# Altium schematic sheet Power Regulator Schematic.SchDoc("Power Regulator Schematic")
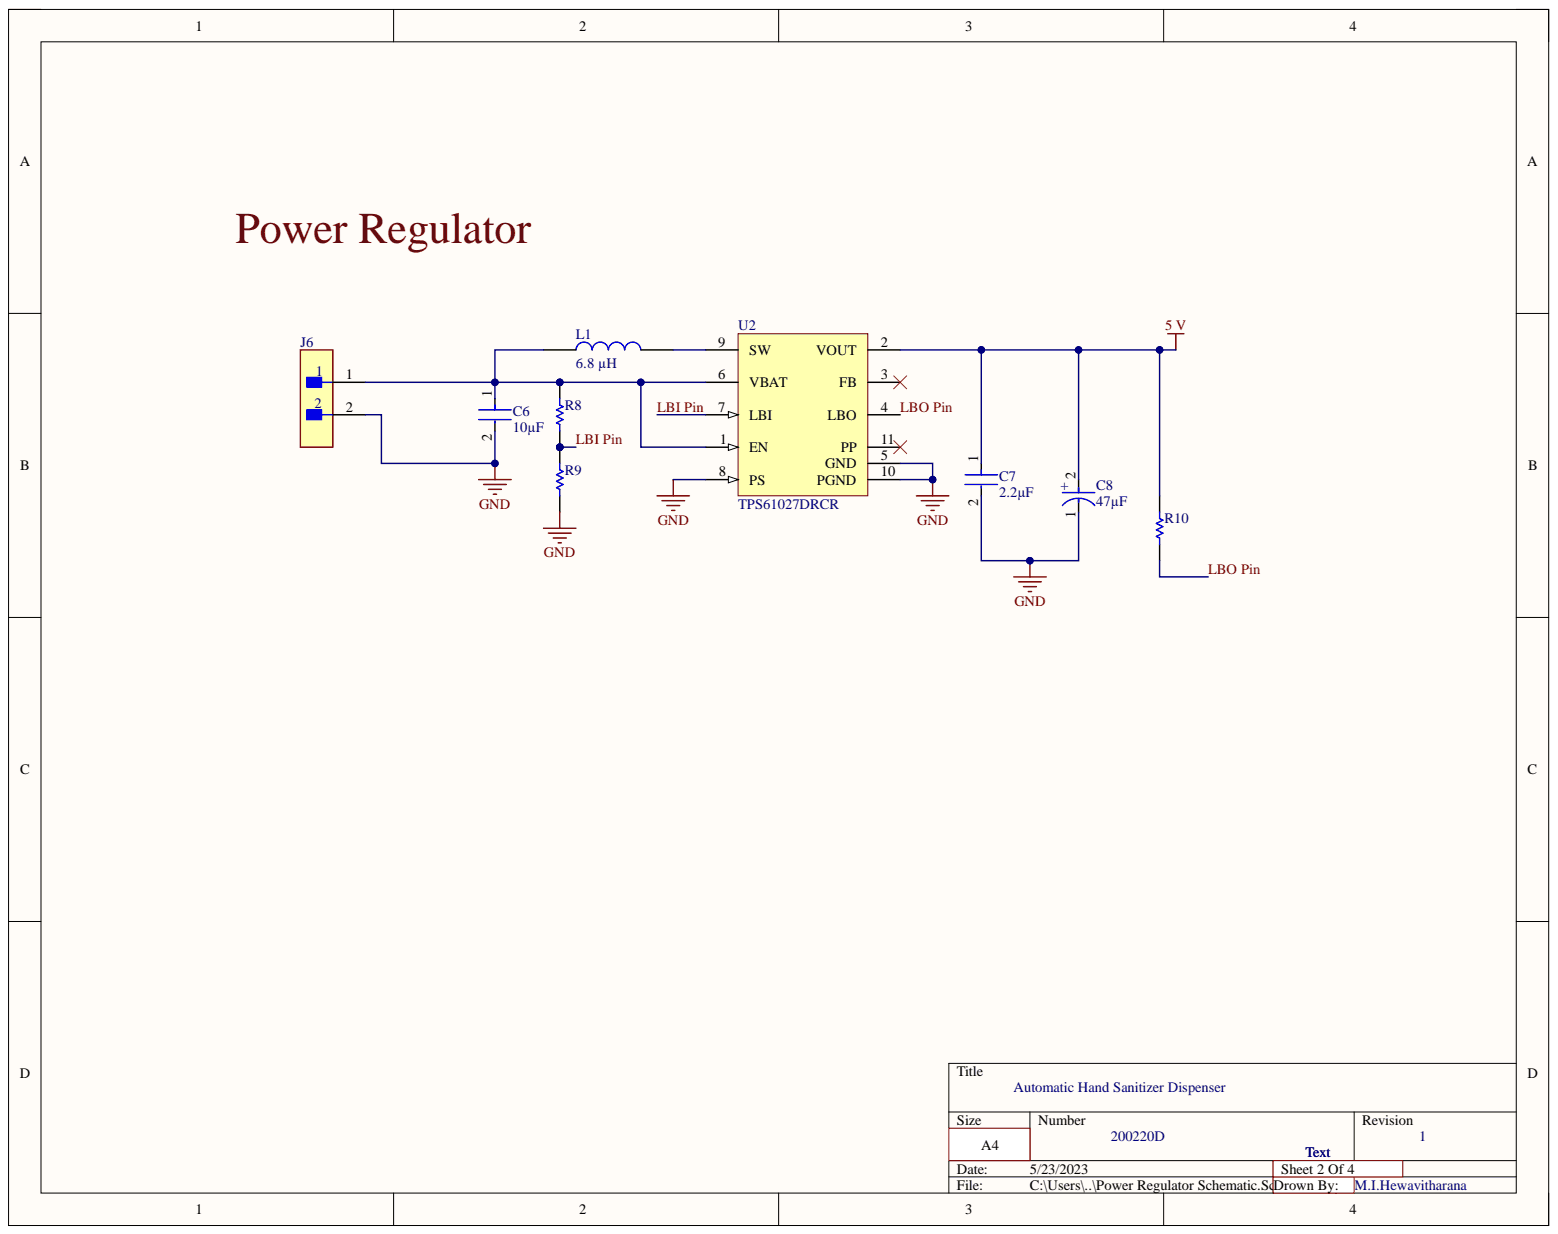
[diagram p0: Power Regulator Schematic.SchDoc]

## Parts
C6  10µF  [FP-VY2472M49Y5UG63V7-MFG]
C7  2.2µF  [FP-VY2472M49Y5UG63V7-MFG]
C8  47µF  [FP-RAD-TH-D_16_0_5-L_25_2-MFG]
J6  TSW-102-07-L-S  [FP-TSW-102-07-L-S-MFG]  (value not drawn)
L1  6.8 µH  [INDPM6363X300N]
R8  MFR-25FBF52-1K  [RESA60-630X240]  (value not drawn)
R9  MFR-25FBF52-1K  [RESA60-630X240]  (value not drawn)
R10  MFR-25FBF52-1K  [RESA60-630X240]  (value not drawn)
U2  TPS61027DRCR  [DRC0010J_V]

## Nets
5 V: C7.1 C8.2 R10.2 U2.2(VOUT)
GND: C6.2 C7.2 C8.1 J6.2 R9.1 U2.5(GND) U2.8(PS) U2.10(PGND)
LBI Pin: R8.1 R9.2 U2.7(LBI)
LBO Pin: R10.1 U2.4(LBO)
NetC6_1: C6.1 J6.1 L1.1 R8.2 U2.1(EN) U2.6(VBAT)
NetL1_2: L1.2 U2.9(SW)

Not connected: U2 3(FB) 11(PP)

## Library data of the parts
C6 C7 = CMP-02417-000047-1: Capacitor Ceramic 4700pF ±20% Y5U BULK RADIAL; Body Diameter: 12.5mm; Capacitance: 4.7nF; Dissipation Factor: 2.5%; Lead Diameter: 0.6mm; Lead/Base Style: Kinked; Voltage Rating: 300V; Case/Package: Radial; Component Kind: Standard; Diameter: 12.5mm; Dielectric: Y5U; Height - Seated (Max): 0.65inch; Lead Pitch: 7.5mm; Library Name: Altium Content Vault; Max Operating Temperature: 125°C; Min Operating Temperature: -40°C; Mount: Through Hole; Package Quantity: 1000; Packaging: Bulk; REACH SVHC: No SVHC; Resistor Type: Ceramic; RoHS Compliant: Yes; Series: VY2; Termination: Radial; Thickness: 5mm; Tolerance: 20%; Voltage Rating (AC): 300V; Voltage Rating (DC): 300V; Weight: 0.040932oz
C8 = CMP-05427-001116-1: Aluminum Electrolytic Capacitors (Radial Lead Type) 47uF ±20% 400V; Dielectric Material: Aluminium; Dissipation Factor: 0.24%; Lead Diameter: 0.8mm; Leakage Current: 1.138mA; Life (Hours): 10000Hour; Ripple Current: 1.18A; Capacitance: 47uF; Case/Package: Radial; Component Kind: Standard; Construction: RADIAL; Diameter: 16mm; Height: 25mm; Height - Seated (Max): 0.984inch; Lead Free: Lead Free; Lead Pitch: 7.5mm; Length: 25mm; Library Name: Altium Content Vault; Max Operating Temperature: 105°C; Min Operating Temperature: -25°C; Mount: Through Hole; Number of Pins: 2; Package Quantity: 100; Packaging: Bulk; Radiation Hardening: No; REACH SVHC: No SVHC; Ripple Current (AC): 1.18A; RoHS Compliant: Yes; Series: EE; Termination: Radial; Tolerance: 20%; Voltage: 400V; Voltage Rating: 400V; Voltage Rating (DC): 400V
J6 = CMP-02766-001428-1: CONN HEADER VERT 2POS 2.54MM; Connector Type: Male Pin; Current Rating: 7.6A; Gender: Male; Max Voltage Rating (AC): 550V; Number of Contacts: 2; Color: Black; Component Kind: Standard; Contact Material: Bronze; Contact Mating Length: 0.23inch; Contact Plating: Gold; Contact Type: Male Pin; Depth: 2.54mm; Housing Color: Black; Housing Material: Polyester; Lead Free: Lead Free; Length: 5.08mm; Library Name: Altium Content Vault; Max Current Rating: 7.6A; Max Operating Temperature: 125°C; Min Operating Temperature: -55°C; Mount: Through Hole; Number of Positions: 2; Number of Rows: 1; Orientation: Straight; Package Quantity: 1; Packaging: Bulk; Pitch: 2.54mm; Radiation Hardening: No; REACH SVHC: No SVHC; RoHS Compliant: Yes; Series: TSW; Termination: Solder; Voltage Rating: 550V; Width: 2.54mm
L1 = B82462G4682M000: SMD / SMT Inductors (Coils), L=6.8uH, 6.3mm x 6.3mm; Manufacturer_Name: TDK; Geometry.Height: 3mm; Arrow Part Number: B82462G4682M000; Arrow Price/Stock: https://www.arrow.com/en/products/b82462g4682m000/epcos-tdk; Component Kind: Standard; Datasheet Link: https://product.tdk.com/system/files/dam/doc/product/inductor/inductor/smd/data_sheet/30/db/ind_2008/b82462g4.pdf; Library Name: Schlib1.SchLib; Manufacturer_Part_Number: B82462G4682M000
R8-R10 = CMP-1659-00075-1: Axial Resistor, 1 KOhm, +/- 1%, 250 mW, -55 to 155 degC, 2-Pin THD, RoHS, Bulk; Power Rating: 250 mW; Resistance: 10 kohm; Voltage Rating: 250 V; Case\Package: Axial; Component Kind: Standard; ComponentLink1Description: Manufacturer URL; ComponentLink1URL: http://www.yageo.com/; ComponentLink2Description: Datasheet; ComponentLink2URL: http://www.yageo.com/documents/recent/Yageo%20LR_MFR_2013.pdf; DatasheetVersion: Apr-13; Length: 0.248 inch; Library Name: Altium Content Vault; Max Operating Temperature: 155 degC; Min Operating Temperature: -55 degC; Package Quantity: 200; PackageDescription: 2-Pin Axial Lead, Dia 2.4 mm, Body Length 6.3 mm; PackageReference: MFR-25; Packaging: Bulk; RoHSCompliant: true; Tolerance: 1%
U2 = CMP-0343-00027-2: Boost Voltage Converter with 0.9 to 6.5 V Input, -40 to 85 degC, 10-Pin SON (DRC), Green (RoHS & no Sb/Br); Manufacturer: Texas Instruments; Preset Vout(V): 5; Regulated Outputs(#): 1; SubFamily: Step-Up Regulator; Switching Frequency(Max)(kHz): 720; Synchronous Rectification: TRUE; Vin(Max)(V): 6.5; Vin(Min)(V): 0.9; Component Kind: Standard; ComponentLink1Description: Datasheet; ComponentLink1URL: http://www.ti.com/general/docs/lit/getliterature.tsp?genericPartNumber=TPS61027&fileType=pdf; ComponentLink2Description: Package Specification; ComponentLink2URL: http://www.ti.com/litv/pdf/mpds117k; DatasheetVersion: SLVS451F; Eco-Plan: Green (RoHS & no Sb/Br); Library Name: Altium Content Vault; Mounting Technology: Surface Mount; PackageDescription: 10-Pin Plastic Small Outline No-Lead, 3 x 3 x 1 mm Body, 0.5 mm Pitch, Exposed Pad; PackageReference: DRC0010J; PackageVersion: revL, Sep-2011; PartNumber: TPS61027DRCR; Topology: Boost
